# Altium schematic sheet MCU Main Circuit.SchDoc
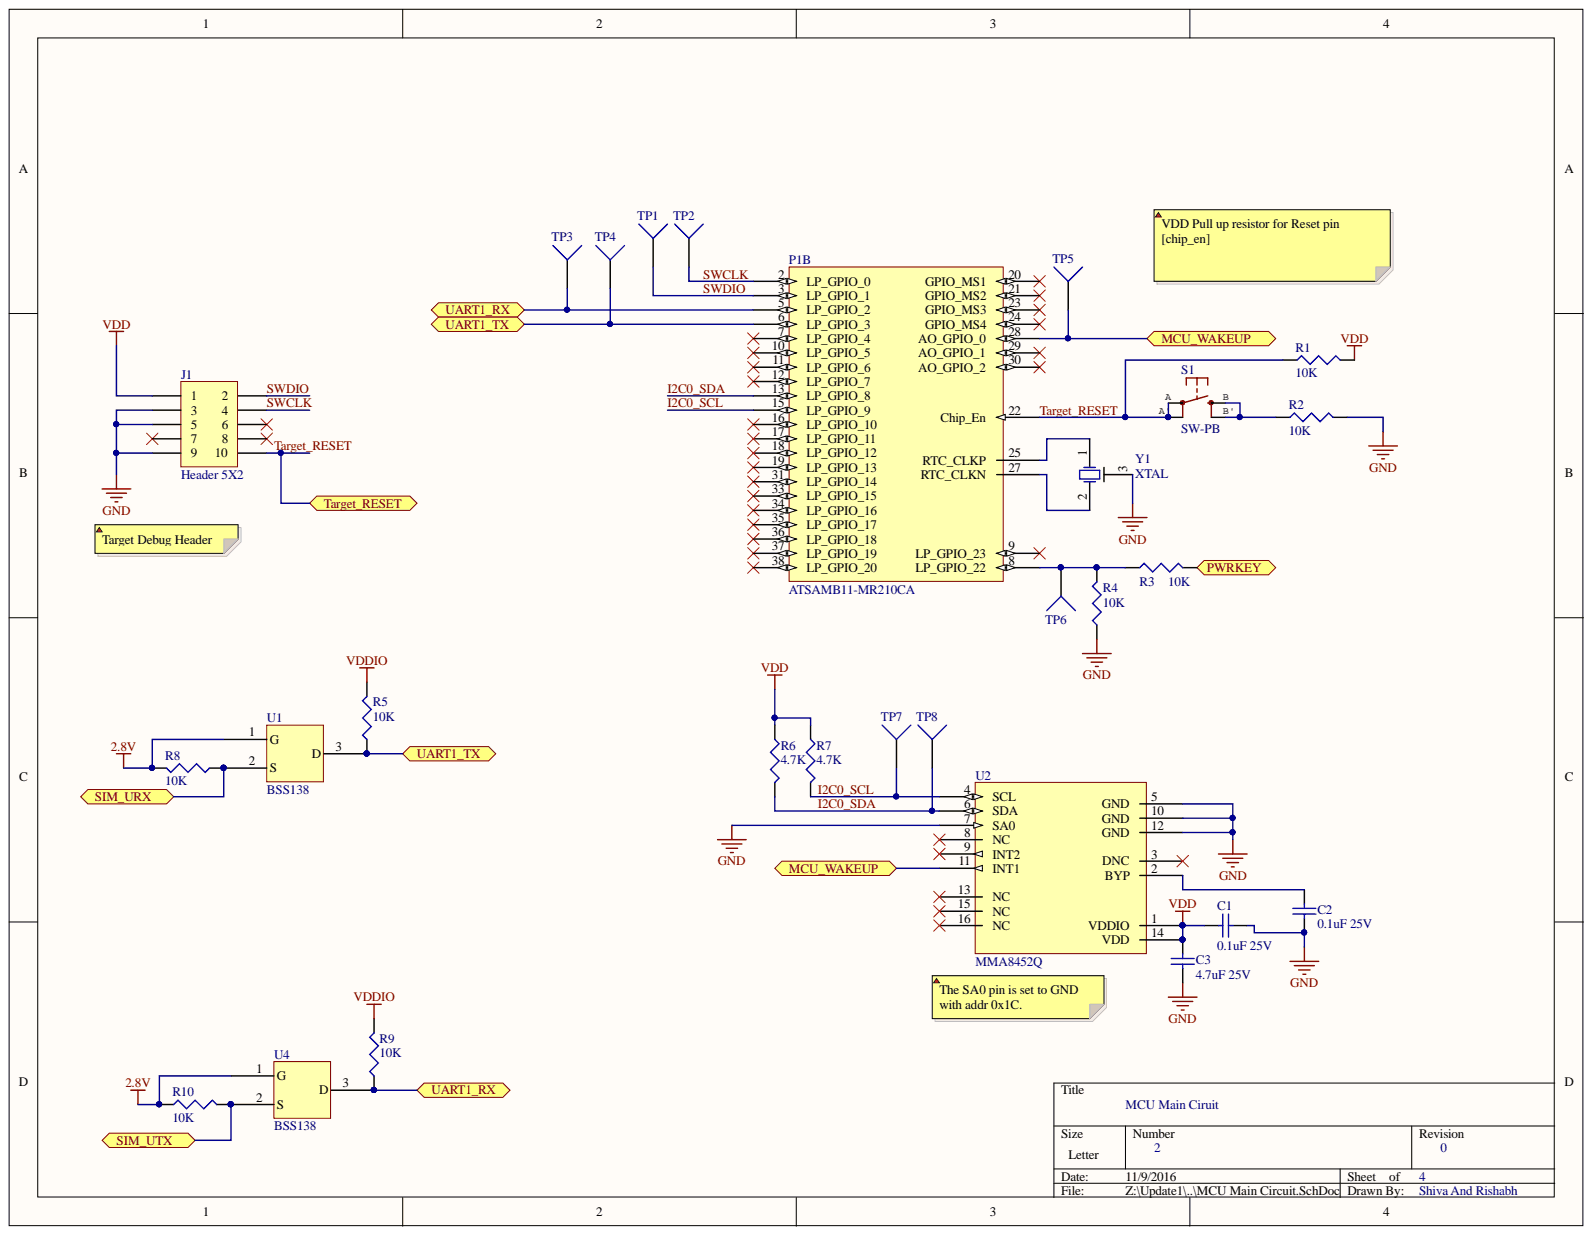
[diagram p0: MCU Main Circuit.SchDoc]

## Parts
C1  value 0.1uF 25V  [Cap_1608[0603]]  (hidden comment: Cap0.1uF)
C2  value 0.1uF 25V  [Cap_1608[0603]]  (hidden comment: Cap0.1uF)
C3  value 4.7uF 25V  [Cap_1608[0603]]  (hidden comment: Cap4.7uF)
J1  Header 5X2  [Cortex Debug Header]
P1  ATSAMB11-MR210CA  [SAMB11_1]  (part B)
R1  value 10K  [Res_0603]  (hidden comment: Res10K)
R2  value 10K  [Res_0603]  (hidden comment: Res10K)
R3  value 10K  [Res_0603]  (hidden comment: Res10K)
R4  value 10K  [Res_0603]  (hidden comment: Res10K)
R5  value 10K  [Res_0603]  (hidden comment: Res10K)
R6  value 4.7K  [Res_0603]  (hidden comment: Res4.7K)
R7  value 4.7K  [Res_0603]  (hidden comment: Res4.7K)
R8  value 10K  [Res_0603]  (hidden comment: Res10K)
R9  value 10K  [Res_0603]  (hidden comment: Res10K)
R10  value 10K  [Res_0603]  (hidden comment: Res10K)
S1  SW-PB  [SPST_PB]
TP1  Test Point  [TESTPOINT_1]  (value not drawn)
TP2  Test Point  [TESTPOINT_1]  (value not drawn)
TP3  Test Point  [TESTPOINT_1]  (value not drawn)
TP4  Test Point  [TESTPOINT_1]  (value not drawn)
TP5  Test Point  [TESTPOINT_1]  (value not drawn)
TP6  Test Point  [TESTPOINT_1]  (value not drawn)
TP7  Test Point  [TESTPOINT_1]  (value not drawn)
TP8  Test Point  [TESTPOINT_1]  (value not drawn)
U1  BSS138  [BSS138_SOT23]
U2  MMA8452Q  [MMA8452Q_QFN32]
U4  BSS138  [BSS138_SOT23]
Y1  XTAL  [XTAL]

## Ports
MCU_WAKEUP, PWRKEY, SIM_URX, SIM_UTX, TARGET_RESET, UART1_RX, UART1_TX

## Nets
2.8V: R8.2 R10.1 U1.1 U4.1
GND: C1.1 C2.1 C3.1 J1.3 J1.5 J1.9 R2.2 R4.1 U2.5(GND) U2.7(SA0) U2.10(GND) U2.12(GND) Y1.3
VDD: C1.2 C3.2 J1.1 R1.2 R6.1 R7.1 U2.1(VDDIO) U2.14(VDD)
VDDIO: R5.2 R9.2
I2C0_SCL: P1.15(LP_GPIO_9) R7.2 TP7.1 U2.4(SCL)
I2C0_SDA: P1.13(LP_GPIO_8) R6.2 TP8.1 U2.6(SDA)
MCU_WAKEUP: P1.28(AO_GPIO_0) TP5.1 U2.11(INT1) ; port MCU_WAKEUP
PWRKEY: R3.2 ; port PWRKEY
SIM_URX: R8.1 U1.2 ; port SIM_URX
SIM_UTX: R10.2 U4.2 ; port SIM_UTX
SWCLK: J1.4 P1.2(LP_GPIO_0) TP2.1
SWDIO: J1.2 P1.3(LP_GPIO_1) TP1.1
TARGET_RESET: J1.10 P1.22(Chip_En) R1.1 S1.A S1.A' ; port TARGET_RESET
UART1_RX: P1.5(LP_GPIO_2) R9.1 TP3.1 U4.3 ; port UART1_RX
UART1_TX: P1.6(LP_GPIO_3) R5.1 TP4.1 U1.3 ; port UART1_TX
NetC2_2: C2.2 U2.2(BYP)
NetP1_8: P1.8(LP_GPIO_22) R3.1 R4.2 TP6.1
NetP1_25: P1.25(RTC_CLKP) Y1.1
NetP1_27: P1.27(RTC_CLKN) Y1.2
NetR2_1: R2.1 S1.B S1.B'

Not connected: J1 6 7 8; P1 7(LP_GPIO_4) 9(LP_GPIO_23) 10(LP_GPIO_5) 11(LP_GPIO_6) 12(LP_GPIO_7) 16(LP_GPIO_10) 17(LP_GPIO_11) 18(LP_GPIO_12) 19(LP_GPIO_13) 20(GPIO_MS1) 21(GPIO_MS2) 23(GPIO_MS3) 24(GPIO_MS4) 29(AO_GPIO_1) 30(AO_GPIO_2) 31(LP_GPIO_14) 33(LP_GPIO_15) 34(LP_GPIO_16) 35(LP_GPIO_17) 36(LP_GPIO_18) 37(LP_GPIO_19) 38(LP_GPIO_20); U2 3(DNC) 8(NC) 9(INT2) 13(NC) 15(NC) 16(NC)

## Library data of the parts
C1 C2 = Cap0.1uF: Capacitor (Semiconductor SIM Model); Manufacturer: Murata Electronics North America; ManufacturerPartNo: GRM188R71E104KA01D; Component Kind: Standard; ComponentLink1Description: Datasheet; ComponentLink1URL: http://search.murata.co.jp/Ceramy/image/img/A01X/G101/ENG/GRM188R71E104KA01-01.pdf; Library Name: LR_Integrated_Library.IntLib; Supplier: Digikey; SupplierLink1URL: http://www.digikey.com/product-detail/en/murata-electronics-north-america/GRM188R71E104KA01D/490-1524-1-ND/587865; SupplierPartNo: 490-1524-1-ND
C3 = Cap4.7uF: Capacitor (Semiconductor SIM Model); Manufacturer: Murata Electronics North America; ManufacturerPartNo: GRM188R61E475KE11D; Component Kind: Standard; ComponentLink1Description: Datasheet; ComponentLink1URL: http://search.murata.co.jp/Ceramy/image/img/A01X/G101/ENG/GRM188R61E475KE11-01.pdf; Library Name: LR_Integrated_Library.IntLib; Supplier: Digikey; SupplierLink1URL: http://www.digikey.com/product-detail/en/murata-electronics-north-america/GRM188R61E475KE11D/490-7203-1-ND/3900450; SupplierPartNo: 490-7203-1-ND
J1 = Header 5X2: Header, 5-Pin, Dual row; Manufacturer: Harwin Inc.; ManufacturerPartNo: M50-3600542; Component Kind: Standard; ComponentLink1Description: Drawing/Datasheet; ComponentLink1URL: https://cdn.harwin.com/pdfs/M50-360.pdf; Library Name: LR_Integrated_Library.IntLib; Supplier: Digikey; SupplierLink1URL: http://www.digikey.com/product-detail/en/harwin-inc/M50-3600542/952-1389-ND/2264370; SupplierPartNo: 952-1389-ND
P1 = ATSAMB11-MR210CA: Atmel BLE SoC Module; Component Kind: Standard; ComponentLink1Description: Datasheet; ComponentLink1URL: http://www.atmel.com/Images/Atmel-42498-SAMB11-MR210CA-MR510_Datasheet.pdf; Library Name: LR_Integrated_Library.IntLib; Manufacturer: Atmel; ManufacturerPartNo: ATSAMB11-MR210CA; Quantity: 1; Supplier: Digikey; SupplierLink1URL: http://www.digikey.com/product-detail/en/atmel/ATSAMB11-MR210CA/ATSAMB11-MR210CA-ND/5638846; SupplierPartNo: ATSAMB11-MR210CA-ND
R1-R5 R8-R10 = Res10K: Resistor; Manufacturer: Susumu; ManufacturerPartNo: RG1608N-103-W-T1; Component Kind: Standard; ComponentLink1Description: Datasheet; ComponentLink1URL: http://www.susumu-usa.com/pdf/RG_RM_RGH_DATASHEET.pdf; Library Name: LR_Integrated_Library.IntLib; Supplier: Digikey; SupplierLink1URL: http://www.digikey.com/product-detail/en/susumu/RG1608N-103-W-T1/RG16N10.0KWCT-ND/600809; SupplierPartNo: RG16N10.0KWCT-ND
R6 R7 = Res4.7K: Resistor; Manufacturer: Samsung Electro-Mechanics America, Inc.; ManufacturerPartNo: RC1608F472CS; Component Kind: Standard; ComponentLink1Description: Datasheet; ComponentLink1URL: http://media.digikey.com/pdf/Data%20Sheets/Samsung%20PDFs/RC_Series_ds.pdf; Library Name: LR_Integrated_Library.IntLib; Supplier: Digikey; SupplierLink1URL: http://www.digikey.com/product-detail/en/samsung-electro-mechanics-america-inc/RC1005F472CS/1276-3466-1-ND/3903569; SupplierPartNo: 1276-3502-1-ND
S1 = SW-PB: Tactile Switch SPST-NO Top Actuated Surface Mount; Manufacturer: C&K Components; ManufacturerPartNo: KMR211GLFS; Component Kind: Standard; ComponentLink1Description: Drawing/Datasheet; ComponentLink1URL: http://www.ckswitches.com/media/1479/kmr2.pdf; Library Name: LR_Integrated_Library.IntLib; Supplier: Digikey; SupplierLink1URL: http://www.digikey.com/product-search/en?keywords=401-1426-1-ND%20; SupplierPartNo: 401-1426-1-ND
U1 U4 = BSS138: MOSFET N-CH 50V 220MA SOT-23; Manufacturer: Fairchild Semiconductor; Component Kind: Standard; ComponentLink1Description: Datasheet; ComponentLink1URL: https://www.fairchildsemi.com/datasheets/BS/BSS138.pdf; Library Name: LR_Integrated_Library.IntLib; ManufacturerPartNo: BSS138; Supplier: Digikey; SupplierLink1URL: http://www.digikey.com/product-detail/en/fairchild-semiconductor/BSS138/BSS138CT-ND/244294; SupplierPartNo: BSS138CT-ND
U2 = MMA8452Q: 3 axis accelerometer; Manufacturer: NXP; ManufacturerPartNo: MMA8452QR1; Component Kind: Standard; ComponentLink1Description: Datasheet; ComponentLink1URL: http://cache.freescale.com/files/sensors/doc/data_sheet/MMA8452Q.pdf; Library Name: LR_Integrated_Library.IntLib; Quantity: 1; Supplier: Digikey; SupplierLink1URL: http://www.digikey.com/product-search/en/sensors-transducers/motion-sensors-accelerometers/1966355?k=mma8452&k=&pkeyword=mma8452&pv7=2&FV=fff4001e%2Cfff80113&mnonly=0&newproducts=0&ColumnSort=0&page=1&quantity=0&ptm=0&fid=0&pageSize=25; SupplierPartNo: MMA8452QR1CT-ND
Y1 = XTAL: 32.768kHz ±20ppm Crystal 7pF 50 kOhm -40°C ~ 85°C Surface Mount Cylindrical Can, Radial; Manufacturer: Citizen Finedevice Co Ltd; ManufacturerPartNo: CMR200T32768DZYT; Component Kind: Standard; ComponentLink1Description: Datasheet; ComponentLink1URL: http://cfd.citizen.co.jp/english/prod-tech/product/pdf/datasheet_TF/CMR200T_E.pdf; Library Name: LR_Integrated_Library.IntLib; Supplier: Digikey; SupplierLink1URL: http://www.digikey.com/product-detail/en/citizen-finedevice-co-ltd/CMR200T32768DZYT/300-8736-1-ND/1679487; SupplierPartNo: 300-8736-1-ND; Value: 32.768kHz
TP1-TP8 = Test Point: Component Kind: Standard; Description: Test Point; LatestRevisionDate: 17-Jul-2002; LatestRevisionNote: Re-released for DXP Platform.; Library Name: LR_Integrated_Library.IntLib; Publisher: Altium Limited
